# Altium schematic sheet USB.SchDoc(U_USB)
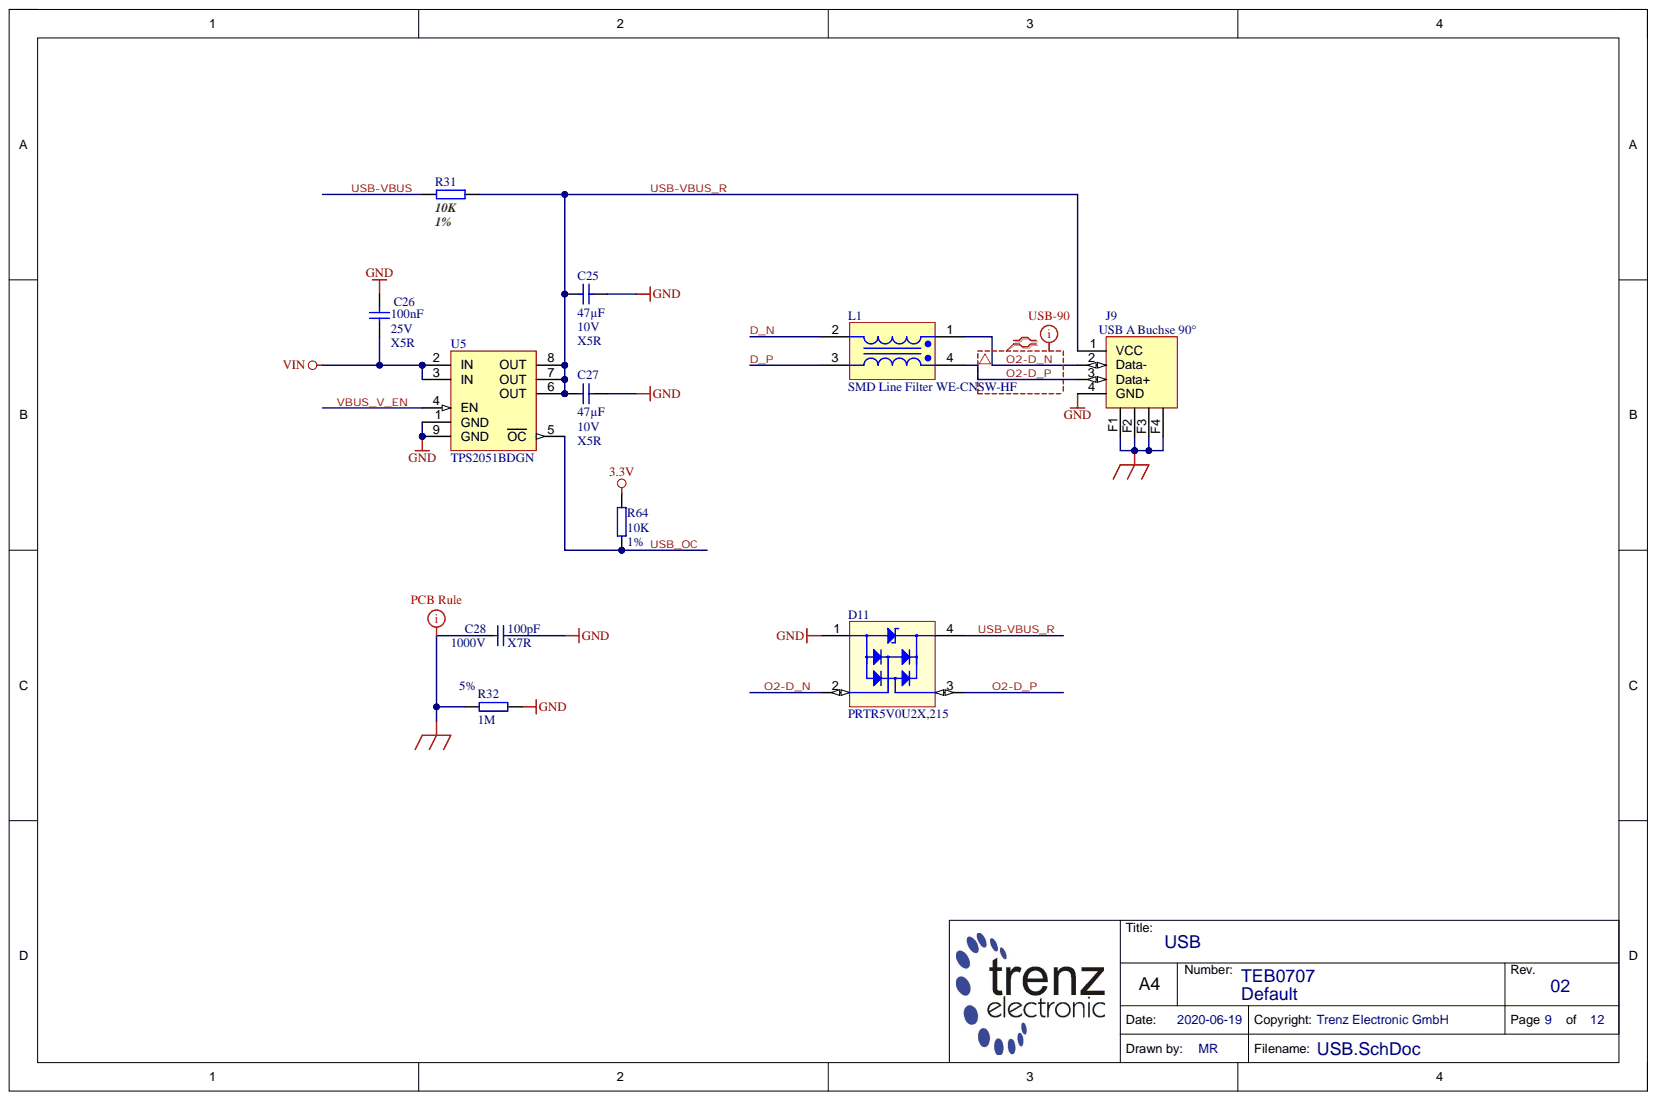
[diagram p0: USB.SchDoc]

## Parts
C25  47µF  Voltage: 10V  [CAPC2012X135N]
C26  100nF  Technology: X5R  Voltage: 25V  [CAPC1005X55N]
C27  47µF  [CAPC2012X135N]
C28  100pF  Technology: X7R  Voltage: 1000V  [CAPC3216X180N]
D11  PRTR5V0U2X,215  [SOT190P229X114-4N]
J9  USB A Buchse 90°  [73725-0110BLF]
L1  SMD Line Filter WE-CNSW-HF  [WE-CNSW-HF]
R31
R31  10K  Tolerance: 1%  [RESC1005X40N]
R32  1M  Tolerance: 5%  [RESC3216X65N]
R64  10K  Tolerance: 1%  [RESC1005X40N]
U5  TPS2051BDGN  [SOP65P488X107-9N-TI]

## Nets
3.3V: R64.2
FGND: C28.1 J9.F1 J9.F2 J9.F3 J9.F4 R32.1
GND: C25.2 C26.2 C27.2 C28.2 D11.1 J9.4(GND) R32.2 U5.1(GND) U5.9(GND)
VIN: C26.1 U5.2(IN) U5.3(IN)
D_N: L1.2
D_P: L1.3
O2-D_N: D11.2 J9.2(Data-) L1.1
O2-D_P: D11.3 J9.3(Data+) L1.4
USB-VBUS: R31.1
USB-VBUS_R: C25.1 C27.1 D11.4 J9.1(VCC) R31.2 U5.6(OUT) U5.7(OUT) U5.8(OUT)
USB_OC: R64.1 U5.5(OC)
VBUS_V_EN: U5.4(EN)

## Library data of the parts
C25 C27 = 26515: KON 47µF 10V  X5R 20% SMD BF 2012 (0805); Database Table Name: C_2012_0805; Mixed: SMT; Temperature-Range: -55/+85; Value: 47u; AN: 26515; Case: 2012 (0805); Component Kind: Standard; Library Name: TE.DbLib; Technology: X5R
  C27: Voltage: 10V
C26 = 22472: KON 100nF 25V X5R ±10% SMD BF 1005 (0402); Database Table Name: C_1005_0402; Mixed: SMT; Preferred: Yes; Temperature-Range: -55/+85; Value: 100n; AN: 22472; Case: 1005 (0402); Component Kind: Standard; Library Name: TE.DbLib
C28 = 22679: KON 100pF 1000V X7R 10%  BF 3216 (1206); Database Table Name: C_3216_1206; Mixed: SMT; Preferred: Yes; Temperature-Range: -55/+125; Value: 100p; AN: 22679; Case: 3216 (1206); Component Kind: Standard; Library Name: TE.DbLib; Tolerance: 10%
D11 = 24840: Database Table Name: DIODE; Extra: Steering/TVS Diode Array Series; Mixed: SMT; Preferred: Yes; Temperature-Range: -40/+85; AN: 24840; Case: SOT143; Component Kind: Standard; Description: PRTR5V0U2X,215; H-ART: PRTR5V0U2X,215; Library Name: TE.DbLib; HelpURL: B:\AD\adlib\datasheet\CD143A-SR05
J9 = 24948: Database Table Name: CON_IO; Mixed: THT; Trenz-Stock: Yes; AN: 24948; Component Kind: Standard; Description: USB A Buchse 90°; Extra: 73725-0110BLF; H-ART: 73725-0110BLF; Library Name: TE.DbLib; HelpURL: B:\AD\adlib\datasheet\73725
L1 = 24514: Stromkompensierter SMD Line Filter HF 320mA 0,24Ohm 50V; Database Table Name: L_SMD; Extra: 67 Ohm bei 100MHz; H-ART: 744233670; Mixed: SMT; Preferred: Yes; AN: 24514; Component Kind: Standard; Library Name: TE.DbLib; Technology: 0.24Ohm; Trenz-Stock: Yes; Voltage: 320mA; HelpURL: B:\AD\adlib\datasheet\WE-CNSW HF
R31 R64 = 22750: WID SMD 10K 1% 0,063W BF 1005 (0402); Database Table Name: R_1005_0402; H-ART: RC0402FR-0710KL; Mixed: SMT; Preferred: Yes; AN: 22750; Case: 1005 (0402); Component Kind: Standard; Library Name: TE.DbLib; Technology: TK100; Value: 10K
R32 = 22757: WID SMD 1M 5% 0,25W  BF 3216 (1206); Database Table Name: R_3216_1206; Mixed: SMT; Preferred: Yes; AN: 22757; Case: 3216 (1206); Component Kind: Standard; Library Name: TE.DbLib; Technology: TK100; Value: 1M
U5 = 25167: Database Table Name: SWITCH; Extra: Leistungschalter mit Strombegrenzung; Mixed: SMT; Preferred: Yes; AN: 25167; Component Kind: Standard; Description: TPS2051BDGN; H-ART: TPS2051BDGN; Library Name: TE.DbLib; Trenz-Stock: Yes; HelpURL: B:\AD\adlib\datasheet\TPS2051BDGN
